# Altium schematic sheet pca20027 - user_interface.SchDoc
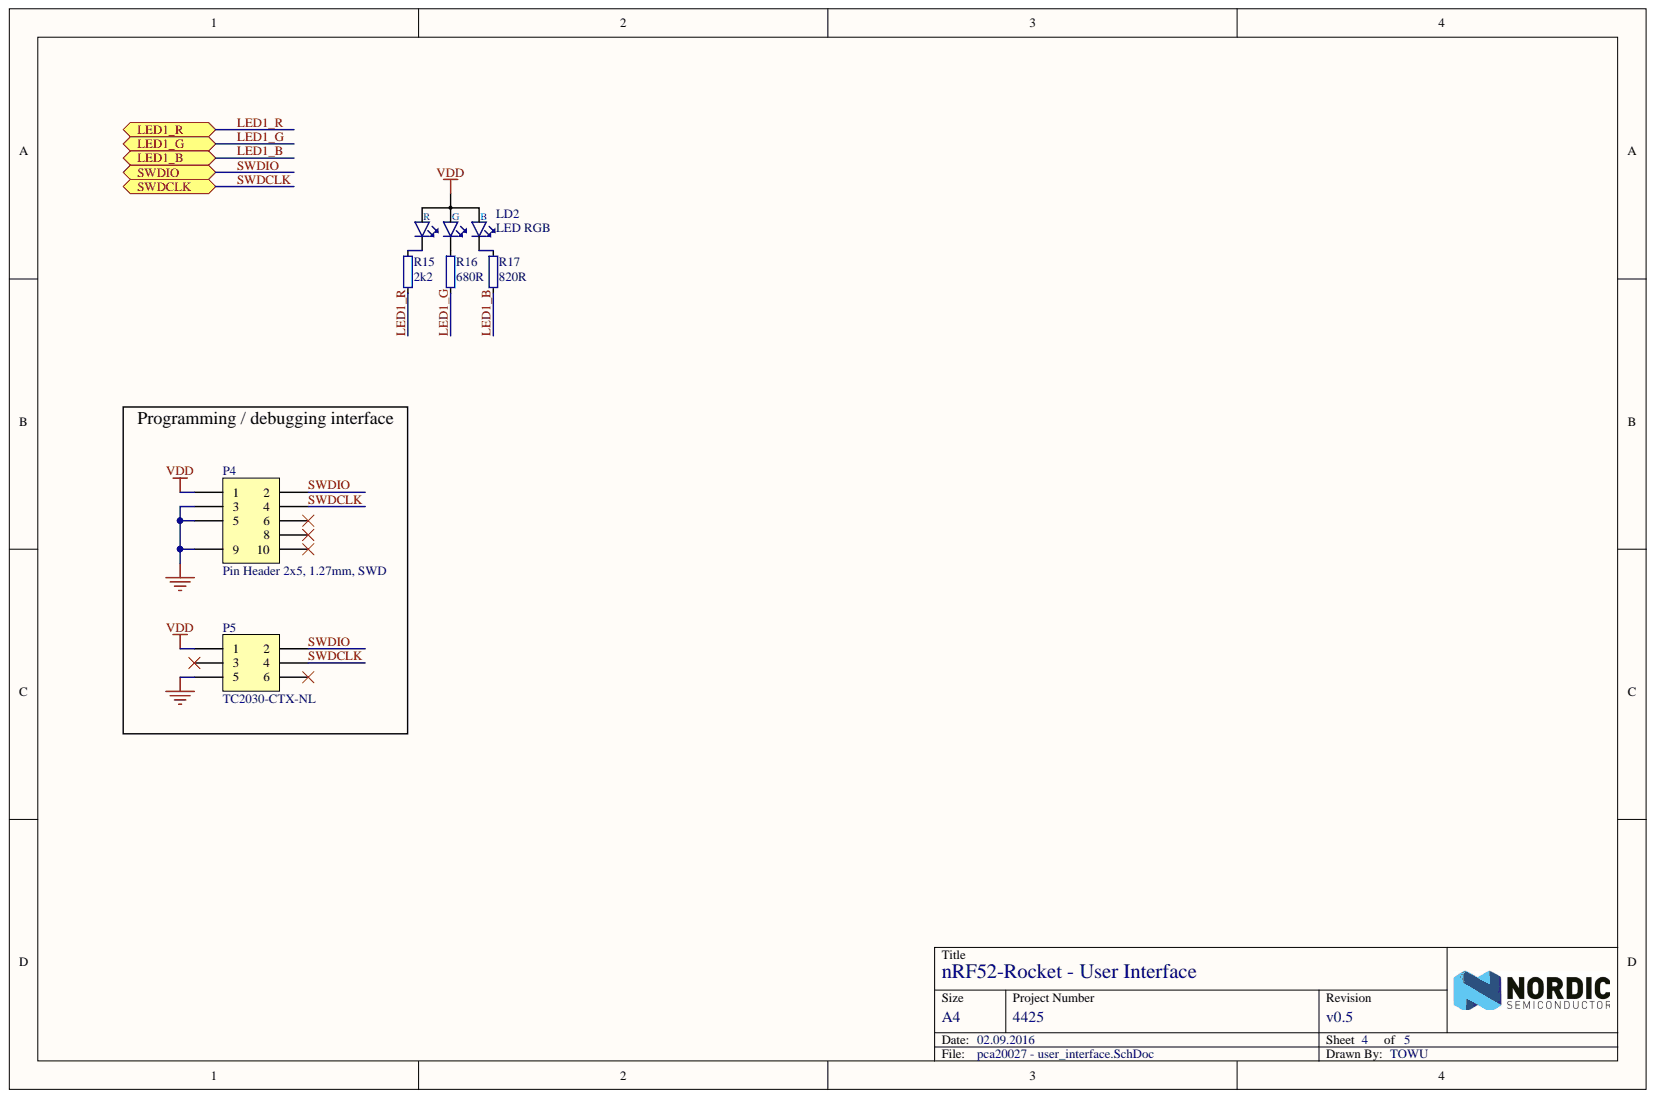
[diagram p0: pca20027 - user_interface.SchDoc]

## Parts
LD2  LED RGB  [SML-LX0404SIUPGUSB]
P4  Pin Header 2x5, 1.27mm, SWD  [HDR_2x5-SMD-1.27mm-K-SWD]
P5  TC2030-CTX-NL  [TC2030-IDC-NLFP]  (no BOM)
R15  2k2  [RESC1608X06L]
R16  680R  [RESC1608X06L]
R17  820R  [RESC1608X06L]

## Ports
LED1_B, LED1_G, LED1_R, SWDCLK, SWDIO

## Nets
GND: P4.3 P4.5 P4.9 P5.5
VDD: LD2.4 P4.1 P5.1
LED1_B: R17.1 ; port LED1_B
LED1_G: R16.1 ; port LED1_G
LED1_R: R15.1 ; port LED1_R
SWDCLK: P4.4 P5.4 ; port SWDCLK
SWDIO: P4.2 P5.2 ; port SWDIO
NetLD2_1: LD2.1 R15.2
NetLD2_2: LD2.2 R16.2
NetLD2_3: LD2.3 R17.2

Not connected: P4 6 8 10; P5 3 6

## Library data of the parts
LD2 = SML-LX0404SIUPGUSB: RGB LED 1.00 mm x 1.00 mm SMD; 2nd source part number: 211-8843; Manufacturer: Lumex; Artikkelnr Noca: 13019150; Artikkelnr Simpro: N.A.; Database Table Name: Optoelectronics$; 2nd source Supplier: Farnell; Component Kind: Standard; Datasheet: http://media.digikey.com/pdf/Data%20Sheets/Lumex%20PDFs/SML-LX0404SIUPGUSB.pdf; Footprint Doc: SML-LX0404SIUPGUSB; LatestRevisionDate: 2012-10-08; Library Name: Nordic Discrete DB.DbLib; Manufacturer Part Number: SML-LX0404SIUPGUSB; Part Number: SML-LX0404SIUPGUSB; Pin Count: 4; Published: 2012-10-08; Supplier: Digi-Key; Supplier part number: 67-2125-1-ND; Value: LED RGB; Publisher: Nordic Semiconductor
P4 = Pin Header 2x5 SMD, 1.27mm, KS, swd: Pin Header 2x5, 1.27mm (50mil), SMD, Keying Shroud, Removed Pin 7; Manufacturer: Samtec; Manufacturer Part Number: FTSH-105-01-F-DV-K; Artikkelnr Noca: N.A.; Database Table Name: Connectors$; Artikkelnr Simpro: N.A.; Component Kind: Standard; Datasheet: http://www.digikey.com/product-detail/en/FTSH-105-01-F-DV-K-P-TR/FTSH-105-01-F-DV-K-P-TR-ND/2649977; Footprint Doc: HDR_2x5-SMD-1.27mm-SWD; LatestRevisionDate: 2016-04-21; Library Name: Nordic Passive DB.DbLib; Part Number: Pin Header 2x5 SMD, 1.27mm, KS, SWD; Pin Count: 10; Published: 2014-05-23; Supplier: Digi-Key; Supplier part number: FTSH-105-01-F-DV-K-P-TR-ND; Value: Pin Header 2x5, 1.27mm, SWD; Publisher: Nordic Semiconductor
P5 = TC2030-CTX-NL: CBL PLUG-OF-NAILS 6-PIN; Manufacturer: Tag-Connect LLC; Artikkelnr Noca: N.A.; Database Table Name: Connectors$; Artikkelnr Simpro: N.A.; Datasheet: http://www.tag-connect.com/Materials/TC2030-IDC-NL.pdf; Footprint Doc: TC2030-IDC-NLFP; LatestRevisionDate: 2013-08-08; Library Name: Nordic Passive DB.DbLib; Manufacturer Part Number: TC2030-CTX-NL; Part Number: TC2030-CTX-NL; Pin Count: 6; Published: 2013-08-08; Supplier: Tag-Connect; Supplier part number: TC2030-CTX-NL; Value: TC2030-CTX-NL; Publisher: Nordic Semiconductor
R15 = R0603-2k2: Resistor, ±1%, 0.06W; Artikkelnr Noca: 27069081; Artikkelnr Simpro: N.A.; Database Table Name: Resistors$; Note: Chip Res 1%; Value Ohm: 2200; Component Kind: Standard; Footprint Doc: 0603; LatestRevisionDate: 2011-08-16; Library Name: Nordic Passive DB.DbLib; Part Number: R0603-2k2; Pin Count: 2; Published: 2009-05-08; Value: 2k2; Publisher: Nordic Semiconductor
R16 = R0603-680: Resistor, ±1%, 0.06W; Artikkelnr Noca: 27069069; Artikkelnr Simpro: N.A.; Database Table Name: Resistors$; Note: Chip Res 1%; Value Ohm: 680; Component Kind: Standard; Footprint Doc: 0603; LatestRevisionDate: 2011-08-16; Library Name: Nordic Passive DB.DbLib; Part Number: R0603-680; Pin Count: 2; Published: 2009-05-08; Value: 680R; Publisher: Nordic Semiconductor
R17 = R0603-820: Resistor, ±1%, 0.06W; Artikkelnr Noca: 27069071; Artikkelnr Simpro: N.A.; Database Table Name: Resistors$; Note: Chip Res 1%; Value Ohm: 820; Component Kind: Standard; Footprint Doc: 0603; LatestRevisionDate: 2011-08-16; Library Name: Nordic Passive DB.DbLib; Part Number: R0603-820; Pin Count: 2; Published: 2009-05-08; Value: 820R; Publisher: Nordic Semiconductor
